# Altium schematic sheet Micro_robot.SchDoc(Micro_robot)
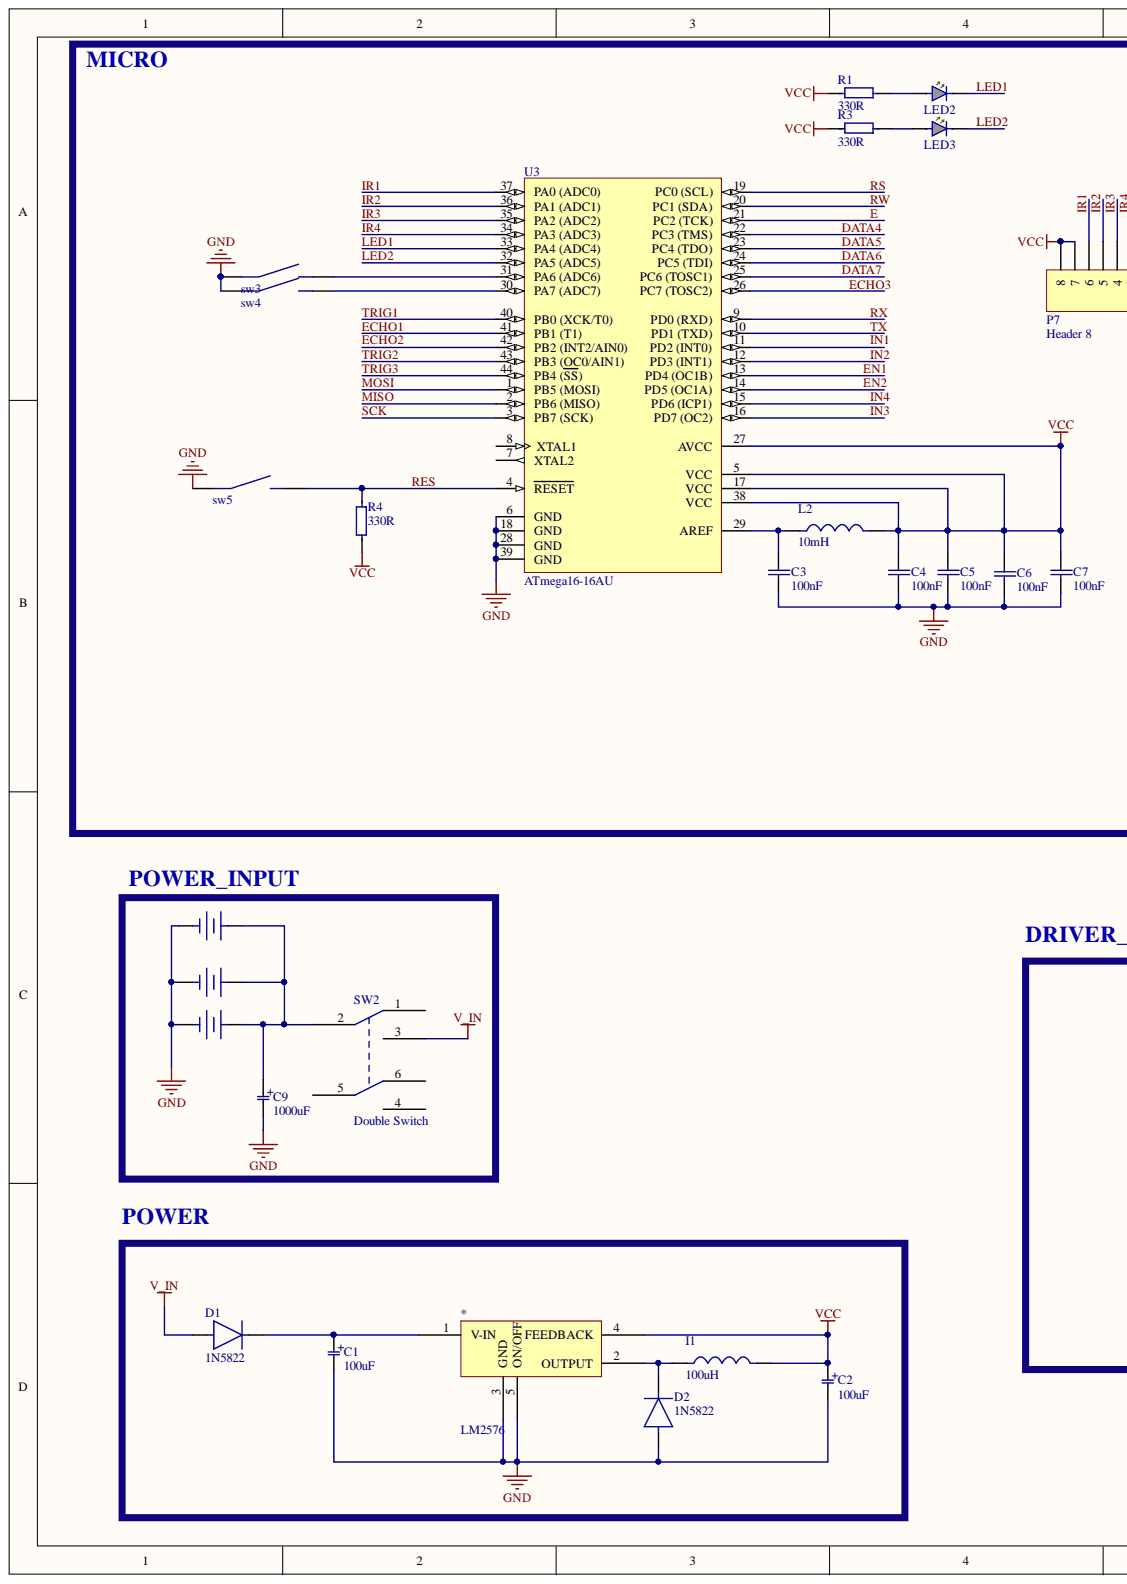
[diagram p0: Micro_robot.SchDoc, left]
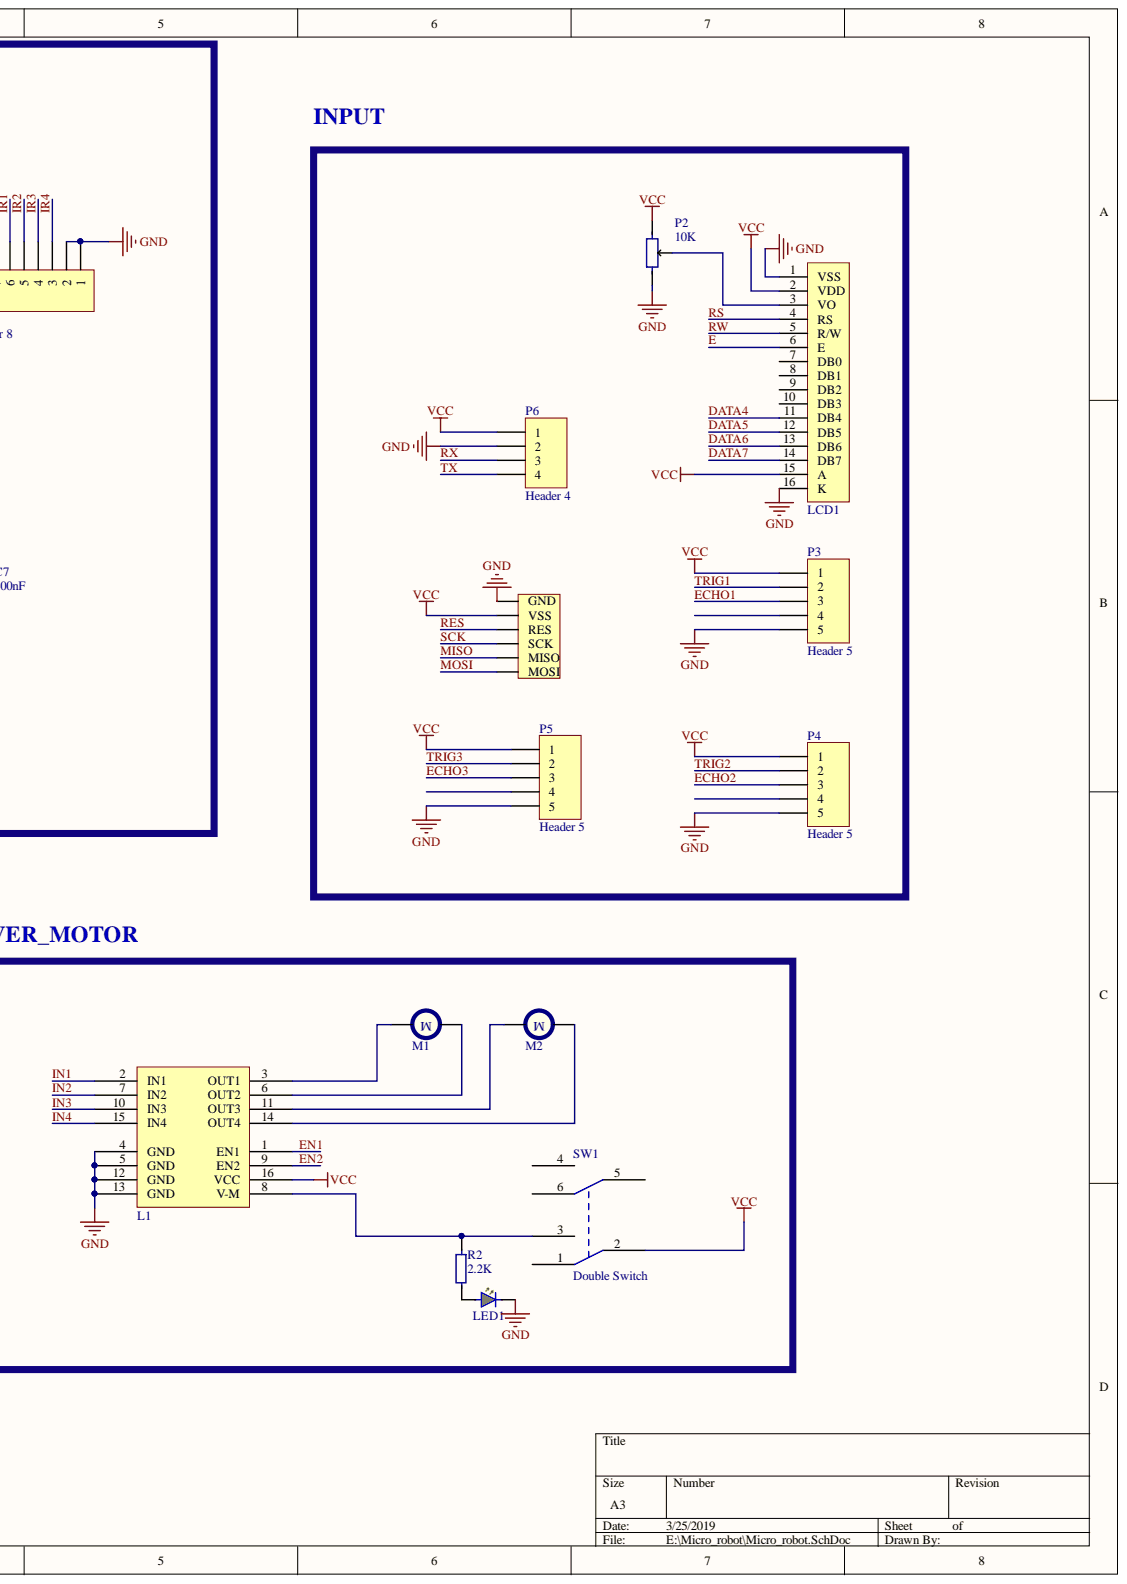
[diagram p1: Micro_robot.SchDoc, right]

## Parts
*  LM2576  [LM2576_SMD]
BAT1  BATTERY  [JACK]  (value not drawn)
BAT2  BATTERY  [CON 2]  (value not drawn)
BAT3  BATTERY  [CON 2]  (value not drawn)
C1  100uF  [CAP POL]
C2  100uF  [CAP POL]
C3  100nF  [CAP-SMD-1206]
C4  100nF  [CAP-SMD-1206]
C5  100nF  [CAP-SMD-1206]
C6  100nF  [CAP-SMD-1206]
C7  100nF  [CAP-SMD-1206]
C9  1000uF  [CAP POL]
D1  1N5822  [DIOD-SMD]
D2  1N5822  [DIOD-SMD]
I1  100uH  [INDUCTOR]
L1  L293  [L293]  (value not drawn)
L2  10mH  [CAP-SMD-1206]
LCD1  LCD 2*16  [LCD2*16]  (value not drawn)
LED1  LED_SMD  [LED-SMD]  (value not drawn)
LED2  LED_SMD  [LED-SMD]  (value not drawn)
LED3  LED_SMD  [LED-SMD]  (value not drawn)
M1  M  [CON 2]
M2  M  [CON 2]
P2  10K  [POT]
P3  Header 5  [HDR1X5]
P4  Header 5  [HDR1X5]
P5  Header 5  [HDR1X5]
P6  Header 4  [HDR1X4]
P7  Header 8  [HDR1X8]
R1  330R  [RES-SMD-805]
R2  2.2K  [RES-SMD-805]
R3  330R  [RES-SMD-805]
R4  330R  [RES-SMD-805]
ROG  PROGRAMMER  [PROGRAMMER]  (value not drawn)
SW1  Double Switch  [Switch - DPDT]
SW2  Double Switch  [Switch - DPDT]
sw3  SW  [SW]  (value not drawn)
sw4  SW  [SW]  (value not drawn)
sw5  SW  [SW]  (value not drawn)
U3  ATmega16-16AU  [atmega16_dip]

## Nets
GND: *.3(GND) *.5(ON/OFF) BAT1.2 BAT2.2 BAT3.2 C1.2 C2.2 C3.2 C4.2 C5.2 C6.2 C7.2 C9.2 D2.1 L1.4(GND) L1.5(GND) L1.12(GND) L1.13(GND) LCD1.1(VSS) LCD1.16(K) LED1.K P2.2 P3.5 P4.5 P5.5 P6.2 P7.1 P7.2 ROG.6(GND) sw3.1 sw4.1 sw5.1 U3.6(GND) U3.18(GND) U3.28(GND) U3.39(GND)
V_IN: D1.1 SW2.3
VCC: *.4(FEEDBACK) C2.1 C4.1 C5.1 C6.1 C7.1 I1.2 L1.16(VCC) L2.2 LCD1.2(VDD) LCD1.15(A) P2.1 P3.1 P4.1 P5.1 P6.1 P7.7 P7.8 R1.1 R3.1 R4.1 ROG.5(VSS) SW1.2 U3.5(VCC) U3.17(VCC) U3.27(AVCC) U3.38(VCC)
DATA4: LCD1.11(DB4) U3.22(PC3_(TMS))
DATA5: LCD1.12(DB5) U3.23(PC4_(TDO))
DATA6: LCD1.13(DB6) U3.24(PC5_(TDI))
DATA7: LCD1.14(DB7) U3.25(PC6_(TOSC1))
E: LCD1.6(E) U3.21(PC2_(TCK))
ECHO1: P3.3 U3.41(PB1_(T1))
ECHO2: P4.3 U3.42(PB2_(INT2/AIN0))
ECHO3: P5.3 U3.26(PC7_(TOSC2))
EN1: L1.1(EN1) U3.13(PD4_(OC1B))
EN2: L1.9(EN2) U3.14(PD5_(OC1A))
IN1: L1.2(IN1) U3.11(PD2_(INT0))
IN2: L1.7(IN2) U3.12(PD3_(INT1))
IN3: L1.10(IN3) U3.16(PD7_(OC2))
IN4: L1.15(IN4) U3.15(PD6_(ICP1))
IR1: P7.6 U3.37(PA0_(ADC0))
IR2: P7.5 U3.36(PA1_(ADC1))
IR3: P7.4 U3.35(PA2_(ADC2))
IR4: P7.3 U3.34(PA3_(ADC3))
LED1: LED2.K U3.33(PA4_(ADC4))
LED2: LED3.K U3.32(PA5_(ADC5))
MISO: ROG.2(MISO) U3.2(PB6_(MISO))
MOSI: ROG.1(MOSI) U3.1(PB5_(MOSI))
RES: R4.2 ROG.4(RES) sw5.2 U3.4(RESET)
RS: LCD1.4(RS) U3.19(PC0_(SCL))
RW: LCD1.5(R/W) U3.20(PC1_(SDA))
RX: P6.3 U3.9(PD0_(RXD))
SCK: ROG.3(SCK) U3.3(PB7_(SCK))
TRIG1: P3.2 U3.40(PB0_(XCK/T0))
TRIG2: P4.2 U3.43(PB3_(OC0/AIN1))
TRIG3: P5.2 U3.44(PB4_(SS))
TX: P6.4 U3.10(PD1_(TXD))
Net*_1: *.1(V-IN) C1.1 D1.2
Net*_2: *.2(OUTPUT) D2.2 I1.1
NetBAT1_1: BAT1.1 BAT2.1 BAT3.1 C9.1 SW2.2
NetC3_1: C3.1 L2.1 U3.29(AREF)
NetL1_3: L1.3(OUT1) M1.2
NetL1_6: L1.6(OUT2) M1.1
NetL1_8: L1.8(V-M) R2.2 SW1.3
NetL1_11: L1.11(OUT3) M2.2
NetL1_14: L1.14(OUT4) M2.1
NetLCD1_3: LCD1.3(VO) P2.3
NetLED1_A: LED1.A R2.1
NetLED2_A: LED2.A R1.2
NetLED3_A: LED3.A R3.2
Netsw3_2: sw3.2 U3.31(PA6_(ADC6))
Netsw4_2: sw4.2 U3.30(PA7_(ADC7))

Not connected: LCD1 7(DB0) 8(DB1) 9(DB2) 10(DB3); P3 4; P4 4; P5 4; SW1 1 4 5 6; SW2 1 4 5 6; U3 7(XTAL2) 8(XTAL1)

## Library data of the parts
C1 C2 C9 = CAP Pol: Library Name: 3D Library.SchLib; Component Kind: Standard
C3-C7 = CAP: Library Name: 3D Library.SchLib; Component Kind: Standard
D1 D2 = DIOD_SMD: Library Name: 3D Library.SchLib; Component Kind: Standard
I1 = INDUCTOR: Component Kind: Standard; Library Name: Skill.SchLib
L2 = Inductor_SMD: Library Name: 3D Library.SchLib; Component Kind: Standard
LED1-LED3 = LED-SMD: Library Name: 3D Library.SchLib; Component Kind: Standard
M1 M2 = motor: Library Name: 3D Library.SchLib; Component Kind: Standard
P2 = POT: Library Name: 3D Library.SchLib; Component Kind: Standard
P3-P5 = Header 5: Header, 5-Pin; Signal Integrity: Connector; Library Name: Miscellaneous Connectors.IntLib; LatestRevisionNote: Re-released for DXP Platform.; LatestRevisionDate: 17-Jul-2002; Component Kind: Standard; Publisher: Altium Limited
P6 = Header 4: Header, 4-Pin; Signal Integrity: Connector; Library Name: Miscellaneous Connectors.IntLib; LatestRevisionNote: Re-released for DXP Platform.; LatestRevisionDate: 17-Jul-2002; Component Kind: Standard; Publisher: Altium Limited
P7 = Header 8: Header, 8-Pin; Signal Integrity: Connector; Library Name: Miscellaneous Connectors.IntLib; LatestRevisionNote: Re-released for DXP Platform.; LatestRevisionDate: 17-Jul-2002; Component Kind: Standard; Publisher: Altium Limited
R1-R4 = RES-SMD: Library Name: 3D Library.SchLib; Component Kind: Standard
SW1 SW2 = Switch - DPDT: DPDT Switch; Library Name: My Library.IntLib; Component Kind: Standard
U3 = ATmega16-16AU: 8-bit AVR Microcontroller, 4.5-5.5V, 16KB Flash, 512 Bytes EEPROM, 1KB SRAM, 44-pin TQFP, Industrial Grade (-40°C to 85°C), Pb-Free; PackageVersion: revC, Oct-2010; PackageReference: 44A; PackageDescription: 44-Pin Plastic Thin Profile Quad Flat Pack, 10 x 10 x 1.0 mm Body, 0.8 mm Pitch (TQFP); Library Name: Atmel Microcontroller megaAVR.IntLib; DatasheetVersion: revT, Jul-2010; ComponentLink3URL: http://www.atmel.com/dyn/resources/prod_documents/2466S.pdf; ComponentLink3Description: ATmega16(L) Summary; ComponentLink2URL: http://www.atmel.com/dyn/resources/prod_documents/doc2466.pdf; ComponentLink2Description: ATmega16(L)  Datasheet; ComponentLink1URL: http://www.atmel.com/; ComponentLink1Description: Manufacturer URL; Component Kind: Standard; Code_JEDEC: MS-026-ACB
* = LM2576: Library Name: 3D Library.SchLib; Component Kind: Standard
BAT1-BAT3 = BATTERY: Library Name: 3D Library.SchLib; Component Kind: Standard
L1 = L293: Library Name: 3D Library.SchLib; Component Kind: Standard
LCD1 = LCD 2*16: Library Name: 3D Library.SchLib; Component Kind: Standard
ROG = PROGRAMMER: Library Name: 3D Library.SchLib; Component Kind: Standard
sw3-sw5 = SW: Library Name: 3D Library.SchLib; Component Kind: Standard
